# Altium schematic sheet AN-DGX - JTAG.SchDoc("AN-DGX - JTAG")
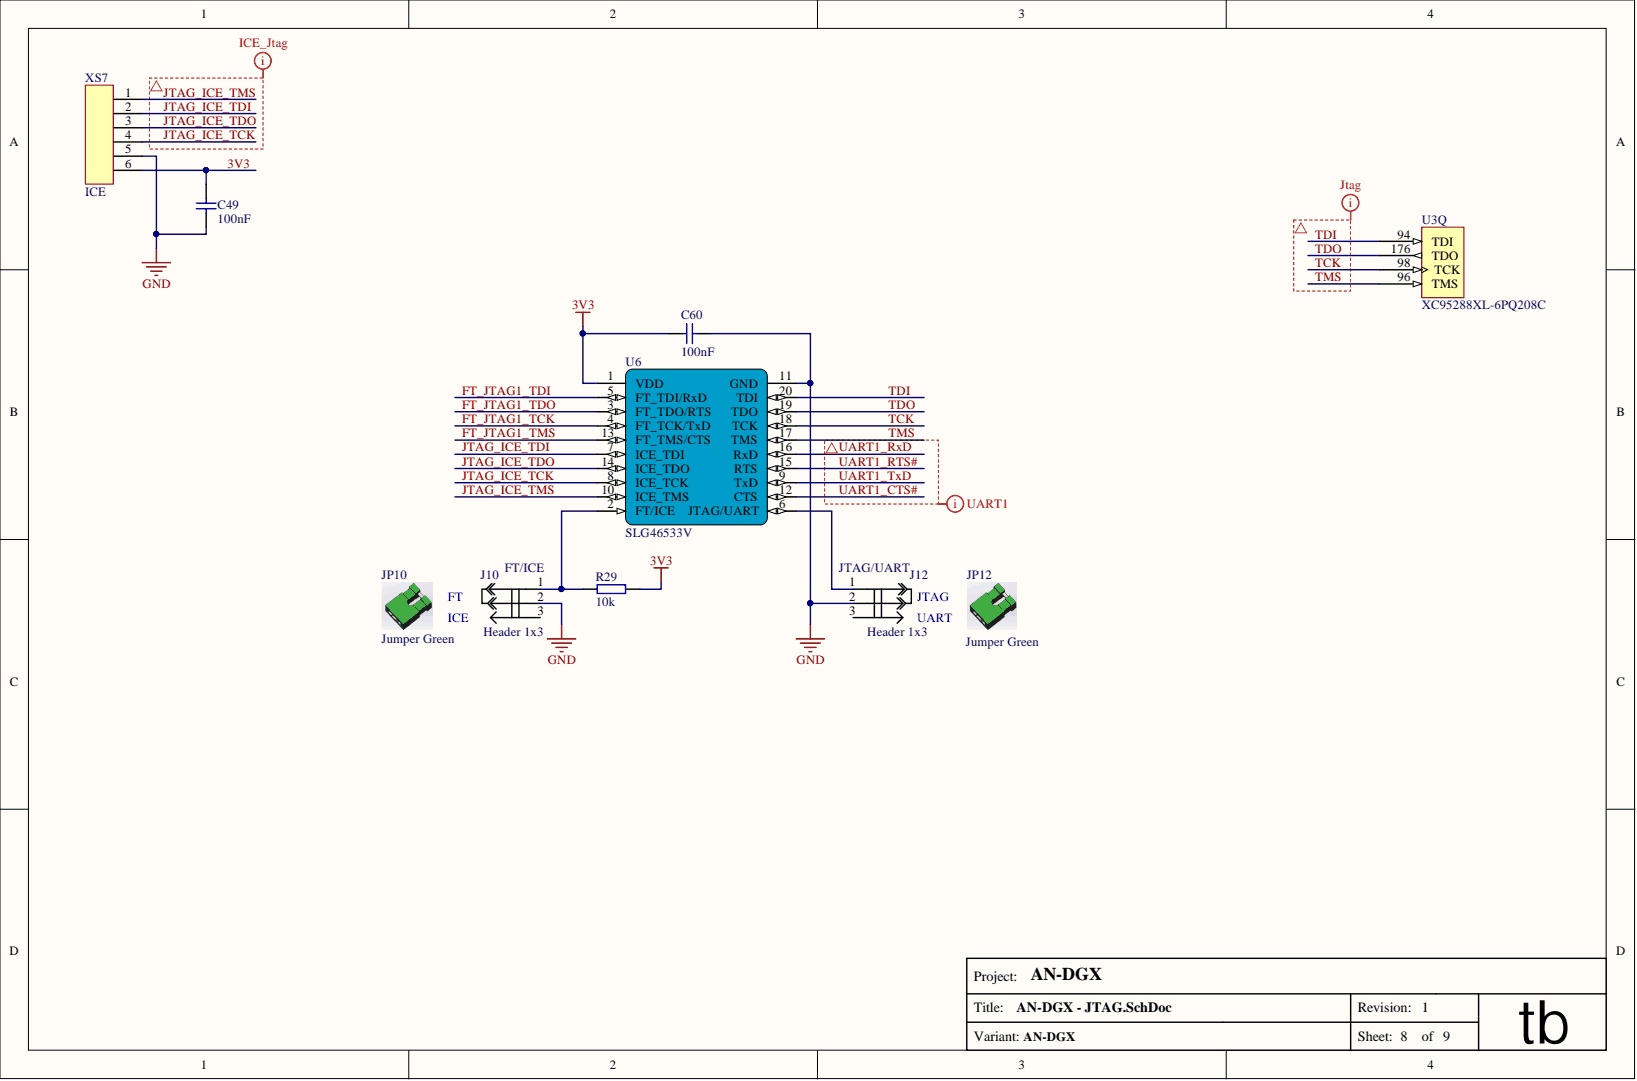
[diagram p0: AN-DGX - JTAG.SchDoc]

## Parts
C49  value 100nF  [C0603]  (hidden comment: CAP)
C60  value 100nF  [C0603]  (hidden comment: CAP)
J10  FT/ICE  value Header 1x3  [Jumper_3pin_green]
J12  JTAG/UART  value Header 1x3  [Jumper_3pin_green]
JP10  value Jumper Green  Supplier 1 Part: Jumper  (hidden comment: VCC_sel)  (mechanical)
JP12  value Jumper Green  Type of Component: Jumper  (hidden comment: VCC_sel)  (mechanical)
R29  value 10k  [0603_RESISTOR]  (hidden comment: Resistor)
U3  XC95288XL-6PQ208C  [PQ208 - XC95288XL]  (part Q)
U6  SLG46533V  [STQFN-20]
XS7  ICE  [Conn_Arduino_6p_2.54_m]

## Nets
GND: C49.1 C60.2 J10.2 J12.2 U6.11(GND) XS7.5
3V3: C49.2 C60.1 R29.1 U6.1(VDD) XS7.6
FT_JTAG1_TCK: U6.4(FT_TCK/TxD)
FT_JTAG1_TDI: U6.5(FT_TDI/RxD)
FT_JTAG1_TDO: U6.3(FT_TDO/RTS)
FT_JTAG1_TMS: U6.13(FT_TMS/CTS)
JTAG_ICE_TCK: U6.8(ICE_TCK) XS7.4
JTAG_ICE_TDI: U6.7(ICE_TDI) XS7.2
JTAG_ICE_TDO: U6.14(ICE_TDO) XS7.3
JTAG_ICE_TMS: U6.10(ICE_TMS) XS7.1
TCK: U3.98(TCK) U6.18(TCK)
TDI: U3.94(TDI) U6.20(TDI)
TDO: U3.176(TDO) U6.19(TDO)
TMS: U3.96(TMS) U6.17(TMS)
UART1_CTS#: U6.12(CTS)
UART1_RTS#: U6.15(RTS)
UART1_RxD: U6.16(RxD)
UART1_TxD: U6.9(TxD)
NetJ10_1: J10.1 R29.2 U6.2(FT/ICE)
NetJ12_1: J12.1 U6.6(JTAG/UART)
N00232:
N00233:
N00234:

Not connected: J10 3; J12 3

## Library data of the parts
C49 C60 = CAP: Type of Component: Ceramic Capacitor; Supplier 3 Part: 001832; Supplier 3 Link: http://voron.ua/catalog/001832; Supplier 3: http://voron.ua; Supplier 2 Part: 182925; Supplier 2 Link: http://imrad.com.ua/ru/cc0603krx7r8bb104; Supplier 2: http://imrad.com.ua/; Supplier 1 Link: aliexpress.com/item/100pcs-lot-100nF-X7R-Error-10-50V-0603-smd-capacitor/32318089455.html?spm=2114.03010208.3.2.PDNk2A&ws_ab_test=searchweb201556_8,searchweb201602_1_10065_10068_112_10069_110_111_418_10017_109_108_10060_10061_10062_10057_10039_10056_10055_10054_10059_10058_10073_10070_10052_10053_10050_10051,searchweb201603_1&btsid=c39062ca-3db8-4562-8db0-c782461646c7; Supplier 1: aliexpress.com; Package / Case: 0603; Component Kind: Standard; Library Name: RPI-VITA.IntLib
J10 J12 = jumper_3pin: Type of Component: Header for jumper 2 pin; Supplier 3 Part: 000631; Supplier 3 Link: http://voron.ua/catalog/000631; Supplier 3: http://voron.ua; Supplier 2 Part: 202826; Supplier 2 Link: http://imrad.com.ua/ru/pls-40-product-122266; Supplier 2: http://imrad.com.ua; Supplier 1 Link: aliexpress.com/item/1Set-20PCS-40Pin-2-54mm-Single-Row-Straight-Male-Pin-Header-Connector-Strip-For-PBC-Ardunio/32329088739.html?spm=2114.03010208.3.2.0ijeiM&ws_ab_test=searchweb201556_1,searchweb201644_1_505_506_503_504_502_10001_10002_10017_10010_10005_10011_10006_10003_10004_10009_10007_10008,searchweb201560_5,searchweb1451318400_-1,searchweb1451318411_6452&btsid=d8fe2734-cbe4-429c-a307-e5400297e31b; Supplier 1: aliexpress.com; Package / Case: Header 1 x 3; Component Kind: Standard; Library Name: RPI-VITA.IntLib
JP10 JP12 = jumper green: Manufacturer Part: Jumper Cap Shunts Short Circuit Cap; Manufacturer: Great Electric; Supplier 1 Link: http://www.aliexpress.com/item/500pcs-lot-Asssorted-Color-2-54mm-Standard-Circuit-Board-Jumper-Cap-Shunts-Short-Circuit-Cap-CGKCH119/32344305619.html?spm=2114.03010208.3.80.nPPmoy&ws_ab_test=searchweb201556_1,searchweb201644_1_505_506_503_504_502_10001_10002_10017_10010_10005_10011_10006_10003_10004_10009_10007_10008,searchweb201560_5,searchweb1451318400_-1,searchweb1451318411_6452&btsid=16d11777-edde-4755-b8b5-9adacb495b09; Supplier 1: aliexpress.com; Package / Case: 2.54mm; Library Name: RPI-VITA.IntLib
  JP10: Type of Component: Jumper Green
  JP12: Supplier 1 Part: Jumper
R29 = Resistor: Manufacturer Part: CRCW060310K0FKEA; Manufacturer: Vishay / Dale; Type of Component: Resistor; Supplier 3 Part: (0603) 10 kom; Supplier 3 Link: http://www.kosmodrom.com.ua/prodlist.php?page=0&name=%280603%29+10+kom&okbutton=%CF%EE%E8%F1%EA+%EF%EE+%F1%EA%EB%E0%E4%F3; Supplier 3: http://www.kosmodrom.com.ua; Supplier 2 Part: 183440; Supplier 2 Link: http://imrad.com.ua/ru/rc0603jr-0710kl; Supplier 2: http://imrad.com.ua/; Supplier 1 Part: 0603 10k; Supplier 1 Link: aliexpress.com/store/product/0603-SMD-Resistor-5-10K-ohm-5000pcs-lot/506373_32307351301.html?storeId=506373&spm=2114.12010208.100007.103.XAQnpm; Supplier 1: aliexpress.com; Package / Case: 0603; Manufacturer Link: http://www.vishay.com/product?docid=20035; Component Kind: Standard; Library Name: RPI-VITA.IntLib
U3 = XC95288XL-6PQ208C: FastFLASH XC900XL, 3.3V High-Performance CPLD with 288 Macrocells, 208-Pin PQFP, Commercial Grade; Manufacturer: Xilinx; Value: XC95288XL-6PQ208C; Type of Component: CPLD; Supplier 1 Part: XC95288XL-6PQ208C; Supplier 1: HK-Ada; Published: 17-Jul-2002; PackageVersion: ver1.2, Jun-2004; PackageReference: PQ208; PackageDescription: 208-Pin Plastic Quad Flat Pack (PQ208); Package / Case: PQ208; Manufacturer Part: XC95288XL-6PQ208C; Manufacturer Link: http://www.xilinx.com/products/silicon_solutions/cplds/xc9500_series/xc9500xl/index.htm; LatestRevisionNote: IPC-7351 Footprint Added.; LatestRevisionDate: 13-Apr-2006; DatasheetVersion: ver2.0, Mar-2006; ComponentLink2URL: http://direct.xilinx.com/bvdocs/publications/ds055.pdf; ComponentLink2Description: Datasheet; ComponentLink1URL: http://www.xilinx.com/products/silicon_solutions/cplds/xc9500_series/xc9500xl/index.htm; ComponentLink1Description: Manufacturer Link; Component Kind: Standard; Code_JEDEC: MS-029-FA-1; Publisher: Altium Limited; Library Name: RPI-VITA.IntLib
U6 = SLG46533: GreenPAK Programmable Mixed Signal Array; Manufacturer: Silego; Type of Component: GPAK-5; Value: SLG46533V; Supplier 1 Part: SLG46533V; Supplier 1 Link: http://www.silego.com/buy/index.php?main_page=product_info&cPath=67&products_id=497; Supplier 1: Silego.com; Package / Case: STQFN-20; Manufacturer Part: SLG46533V; Manufacturer Link: http://www.silego.com/products/greenpak5.html; Component Kind: Standard; Library Name: RPI-VITA.IntLib
XS7 = conn_Arduino_6p: PLS-6 2.54mm Pitch, 10.5mm High; Value: Header 1x6; Type of Component: Header 1x6; Supplier 3 Part: 000631; Supplier 3 Link: http://voron.ua/catalog/000631; Supplier 3: http://voron.ua; Supplier 2 Part: 202826; Supplier 2 Link: http://imrad.com.ua/ru/pls-40-product-122266; Supplier 2: http://imrad.com.ua; Supplier 1 Link: aliexpress.com/item/1Set-20PCS-40Pin-2-54mm-Single-Row-Straight-Male-Pin-Header-Connector-Strip-For-PBC-Ardunio/32329088739.html?spm=2114.03010208.3.2.0ijeiM&ws_ab_test=searchweb201556_1,searchweb201644_1_505_506_503_504_502_10001_10002_10017_10010_10005_10011_10006_10003_10004_10009_10007_10008,searchweb201560_5,searchweb1451318400_-1,searchweb1451318411_6452&btsid=d8fe2734-cbe4-429c-a307-e5400297e31b; Supplier 1: aliexpress.com; Package / Case: Header 1x6; Component Kind: Standard; Library Name: RPI-VITA.IntLib
